# Altium schematic sheet Connectors.SchDoc(Connectors)
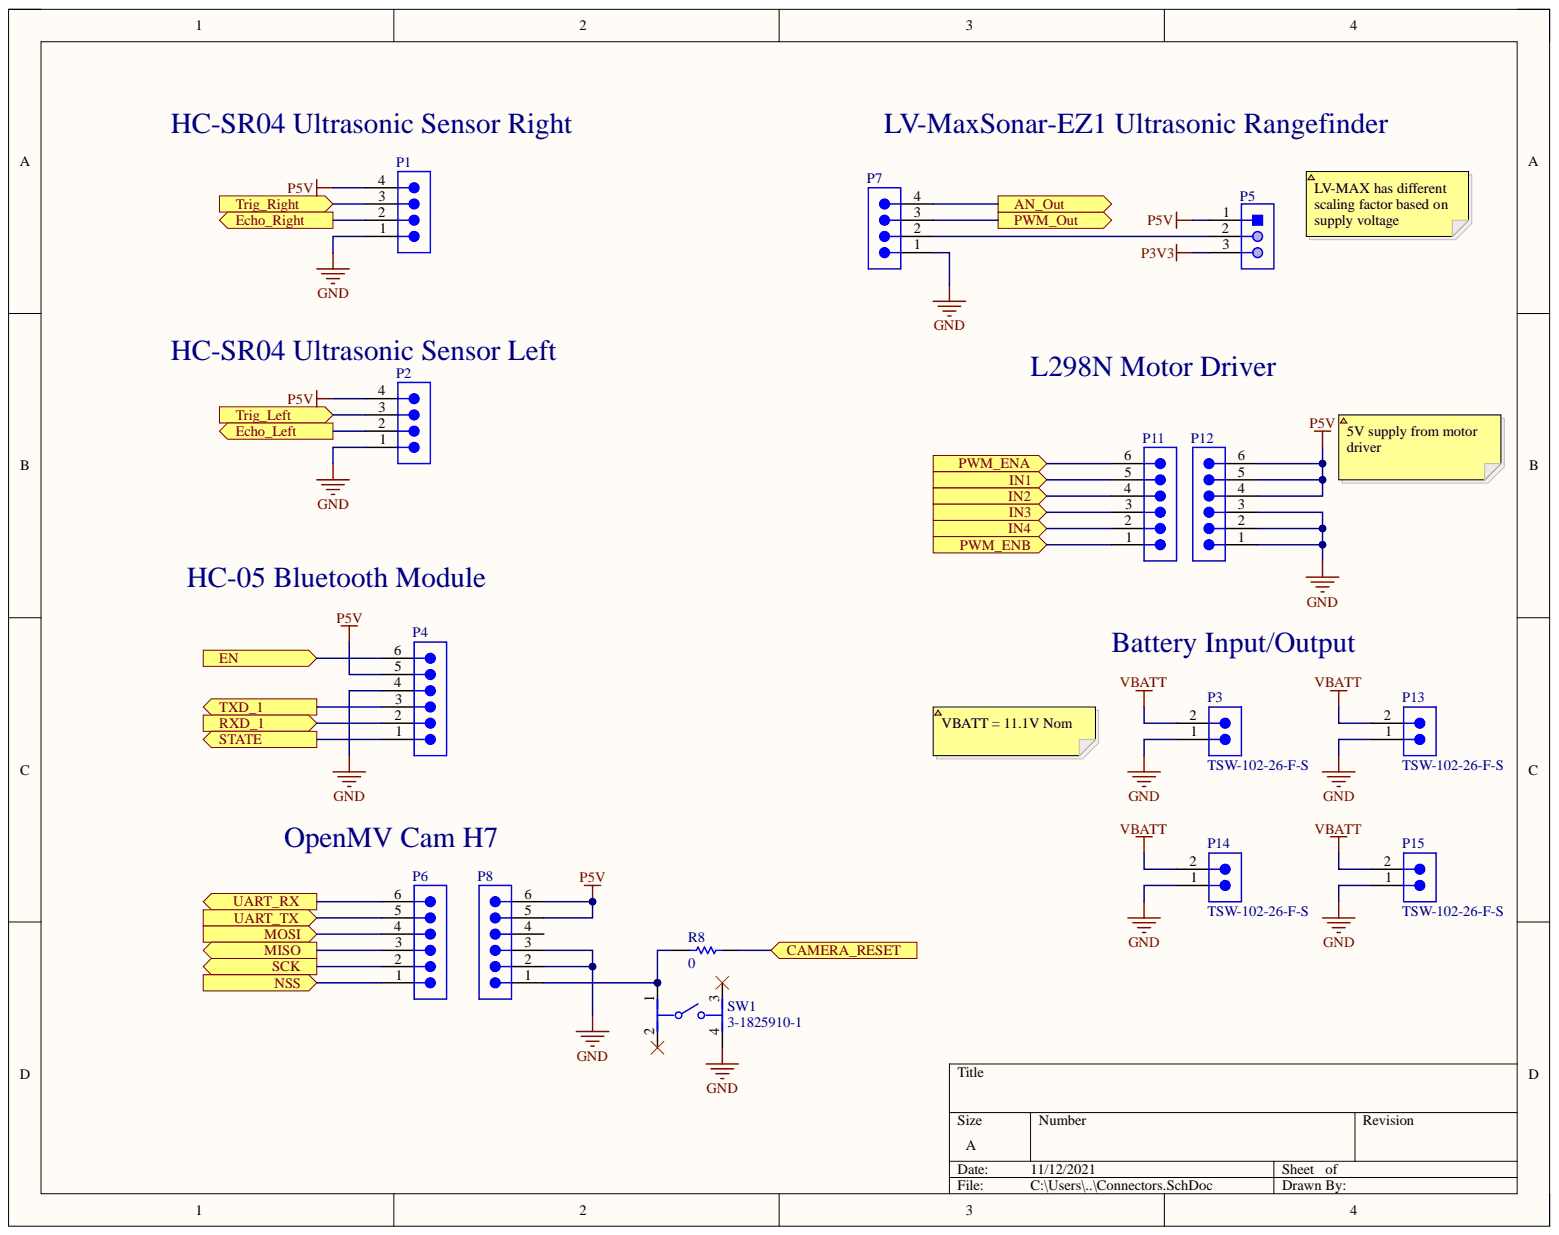
[diagram p0: Connectors.SchDoc]

## Parts
P1  TSW-104-08-T-S  [SMTC-TSW-104-08-X-S]  (value not drawn)
P2  TSW-104-08-T-S  [SMTC-TSW-104-08-X-S]  (value not drawn)
P3  TSW-102-26-F-S  [SMTC-TSW-102-26-XX-S]
P4  TSW-106-23-G-S  [SMTC-TSW-106-23-X-S]  (value not drawn)
P5  87224-3  [TECO-87224-3_V]  (value not drawn)
P6  TSW-106-23-G-S  [SMTC-TSW-106-23-X-S]  (value not drawn)
P7  TSW-104-08-T-S  [SMTC-TSW-104-08-X-S]  (value not drawn)
P8  TSW-106-23-G-S  [SMTC-TSW-106-23-X-S]  (value not drawn)
P11  TSW-106-23-G-S  [SMTC-TSW-106-23-X-S]  (value not drawn)
P12  TSW-106-23-G-S  [SMTC-TSW-106-23-X-S]  (value not drawn)
P13  TSW-102-26-F-S  [SMTC-TSW-102-26-XX-S]
P14  TSW-102-26-F-S  [SMTC-TSW-102-26-XX-S]
P15  TSW-102-26-F-S  [SMTC-TSW-102-26-XX-S]
R8  value 0  [RESC3216X60X45ML15T20]  (hidden comment: Resistor 0R +/- 5% 1206 250 mW)
SW1  3-1825910-1  [FP-3-1825910-1-MFG]

## Ports
AN_Out, CAMERA_RESET, Echo_Left, Echo_Right, EN, IN1, IN2, IN3, IN4, MISO, MOSI, NSS, PWM_ENA, PWM_ENB, PWM_Out, RXD_1, SCK, STATE, Trig_Left, Trig_Right, TXD_1, UART_RX, UART_TX

## Nets
GND: P1.1 P2.1 P3.1 P4.4 P7.1 P8.2 P8.3 P12.1 P12.2 P12.3 P13.1 P14.1 P15.1 SW1.4
P3V3: P5.3
P5V: P1.4 P2.4 P4.5 P5.1 P8.5 P8.6 P12.4 P12.5 P12.6
VBATT: P3.2 P13.2 P14.2 P15.2
AN_Out: P7.4 ; port AN_Out
CAMERA_RESET: R8.2 ; port CAMERA_RESET
Echo_Left: P2.2 ; port Echo_Left
Echo_Right: P1.2 ; port Echo_Right
EN: P4.6 ; port EN
IN1: P11.5 ; port IN1
IN2: P11.4 ; port IN2
IN3: P11.3 ; port IN3
IN4: P11.2 ; port IN4
MISO: P6.3 ; port MISO
MOSI: P6.4 ; port MOSI
NSS: P6.1 ; port NSS
PWM_ENA: P11.6 ; port PWM_ENA
PWM_ENB: P11.1 ; port PWM_ENB
PWM_Out: P7.3 ; port PWM_Out
RXD_1: P4.2 ; port RXD_1
SCK: P6.2 ; port SCK
STATE: P4.1 ; port STATE
Trig_Left: P2.3 ; port Trig_Left
Trig_Right: P1.3 ; port Trig_Right
TXD_1: P4.3 ; port TXD_1
UART_RX: P6.6 ; port UART_RX
UART_TX: P6.5 ; port UART_TX
NetP5_2: P5.2 P7.2
NetP8_1: P8.1 R8.1 SW1.1

Not connected: P8 4; SW1 2 3

## Library data of the parts
P1 P2 P7 = CMP-1024-00356-1: 0.025" SQ Post Header, Through-hole, Vertical, -55 to 105 degC, 2.54 mm Pitch, 4-Pin, Male, RoHS; Manufacturer: Samtec; Pitch: 2.54 mm; Component Kind: Standard; ComponentLink1Description: Manufacturer URL; ComponentLink1URL: http://www.samtec.com; ComponentLink2Description: Datasheet; ComponentLink2URL: http://www.samtec.com/documents/webfiles/pdf/TSW_TH.PDF; ComponentLink3Description: Package Specification; ComponentLink3URL: http://www.samtec.com/documents/webfiles/cpdf/TSW-XXX-XX-XXX-X-XX-XXX-MKT.pdf; DatasheetVersion: F-214; Library Name: Altium Content Vault; Mounting Technology: Through-hole; Orientation: Vertical; PackageDescription: .025[0.64] SQ. Post Terminal Strip Assembly; PackageReference: TSW-104-08-X-S; PackageVersion: DM, 8/1988; PartNumber: TSW-104-08-T-S; RoHS: True; Temperature Range: -55 to 105 degC
P3 P13-P15 = CMP-002-00072-1: Headers & Wire Housings Classic PCB Header Strips, 0.100 pitch; Contact Post Length: 3.2mm; Gender: Male; Max Current Rating: 7.6A; Max Voltage Rating (AC): 550V; Number of Contacts: 2; Pitch: 2.54mm; Voltage Rating: 500V; Component Kind: Standard; Contact Gender: Male; Contact Material: Bronze; Contact Mating Length: 5.84mm; Contact Plating: Gold; Contact Type: -; Depth: 2.54mm; Flammability Rating: UL94 V-0; Housing Color: Black; Housing Material: Polyester; Length: 5.08mm; Max Operating Temperature: 125°C; Min Operating Temperature: -55°C; Number of Positions: 2; Number of Rows: 1; Orientation: Vertical; Package Quantity: 1; Packaging: Bulk; Radiation Hardening: No; REACH SVHC: No SVHC; RoHS Compliant: Yes; Series: TSW; Termination: Through Hole; Weight: 0.001257oz; Library Name: University of California Riverside - FSAE
P4 P6 P8 P11 P12 = CMP-1024-00396-1: 0.025" SQ Post Header, Through-hole, Vertical, -55 to 125 degC, 2.54 mm Pitch, 6-Pin, Male, RoHS; Manufacturer: Samtec; Pitch: 2.54 mm; Component Kind: Standard; ComponentLink1Description: Manufacturer URL; ComponentLink1URL: http://www.samtec.com; ComponentLink2Description: Datasheet; ComponentLink2URL: http://www.samtec.com/documents/webfiles/pdf/TSW_TH.PDF; ComponentLink3Description: Package Specification; ComponentLink3URL: http://www.samtec.com/documents/webfiles/cpdf/TSW-XXX-XX-XXX-X-XX-XXX-MKT.pdf; DatasheetVersion: F-214; Library Name: Altium Content Vault; Mounting Technology: Through-hole; Orientation: Vertical; PackageDescription: .025[0.64] SQ. Post Terminal Strip Assembly; PackageReference: TSW-106-23-X-S; PackageVersion: DM, 8/1988; PartNumber: TSW-106-23-G-S; RoHS: True; Temperature Range: -55 to 125 degC
P5 = CMP-2000-05697-1: Male Header, Pitch 2.54 mm, 1 x 3 Position, Height 10.744 mm, Tail Length 3.175 mm, -65 to 105 degC, RoHS, Bulk; Manufacturer: TE Connectivity; 3D Model: 87224-3.pdf; Catalog Drawings: SingleSquareStraightPost    SingleSquareStraightPostSide; Connector Type: Header,Unshrouded; Dynamic Catalog: AMPMODUModIISeries; Featured Product: AMPMODUConnectors; Mating Products: 147738-2-ND  -CONNRCPT3POSVERTSMDGOLD  147723-2-ND  -CONNRCPT3POSVERT.100GOLD  146763-5-ND  -CONNRCPT3POSSGLVERT.100T/H  A32904-ND  -CONNRECEPT3POS.100VERTGOLD  A26922-ND  -CONNHOUSING3POS.100LOCKING  A26415-ND  -CONNRECEPT3POS.100VERTAU  A26964-ND  -CONNHOUSING3POS.100SINGLE  A3012-ND  -CONNHOUSING3POS.100SINGLE; Number of Positions: 3; Number of Positions Loaded: All; Other Names: 87224-3-ND  A26545; Pitch: 0.100"(2.54mm); Category: Connectors,Interconnects; Color: Black; Component Kind: Standard; Contact Finish: Gold; Contact Finish Thickness: 15µin(0.38µm); Contact Mating Length: 0.318"(8.08mm); Contact Type: MalePin; Datasheet URL: http://www.te.com/commerce/DocumentDelivery/DDEController?Action=showdoc&DocId=Customer+Drawing%7F87224%7FL3%7Fpdf%7FEnglish%7FENG_CD_87224_L3.pdf%7F87224-3; Datasheet Version: Rev. L3, 08/2015; Family: RectangularConnectors-Headers,MalePins; Library Name: Altium Content Vault; Manufacturer URL: http://www.te.com/; Mounting Technology: ThroughHole; Mounting Type: ThroughHole; Number of Rows: 1; Package Description: Male Header, Pitch 2.54 mm, 1 x 3 Position, Height 10.744 mm, Tail Length 3.175 mm; Package Reference: 87224-3; Packaging: Bulk; RoHS Information: 87224-3StatementofCompliance; Series: AMPMODUModII; Standard Package: 520; Termination: Solder
R8 = CMP-009-00002-4: Chip Resistor, 0 Ohm, +/- 5%, 0.25 W, -55 to 155 degC, 1206 (3216 Metric); Power: 250mW; Voltage Rating: 200V; Case/Package: 1206; Component Kind: Standard; Max Operating Temperature: 155°C; Min Operating Temperature: -55°C; Mounting Technology: SM; Pins: 2; RoHS Compliant: True; Tolerance: 5%; Library Name: University of California Riverside - FSAE
SW1 = CMP-03407-000001-1: SWITCH TACTILE SPST-NO 0.05A 24V; Manufacturer: TE Connectivity; Contact Current Rating: 50mA; Contact Resistance: 100mΩ; Electromechanical Life: 100000Cycles; Operating Force: 160gf; Automotive: No; Category: Switch; Component Kind: Standard; ComponentLink2Description: Datasheet; ComponentLink2URL: https://www.te.com/commerce/DocumentDelivery/DDEController?Action=srchrtrv&DocNm=1825910&DocType=Customer+Drawing&DocLang=English; Device Class L1: Electromechanical; Device Class L2: Switches; Device Class L3: Tactile Switches; Digikey Description: SWITCH TACTILE SPST-NO 0.05A 24V; Height: 17.3mm; Lead Free: Yes; Library Name: Altium Content Vault; Manufacturer Part Number: 3-1825910-1; Mount: Through Hole; Octopart: https://octopart.com/3-1825910-1-te+connectivity-46506874; Package: 0; RoHS: TRUE; Temperature: -35°C to +85°C; Temperature Range High: +85°C; Temperature Range Low: -35°C; Throw Configuration: SPST-NO
